# Altium schematic sheet Pwr.SchDoc(Pwr)
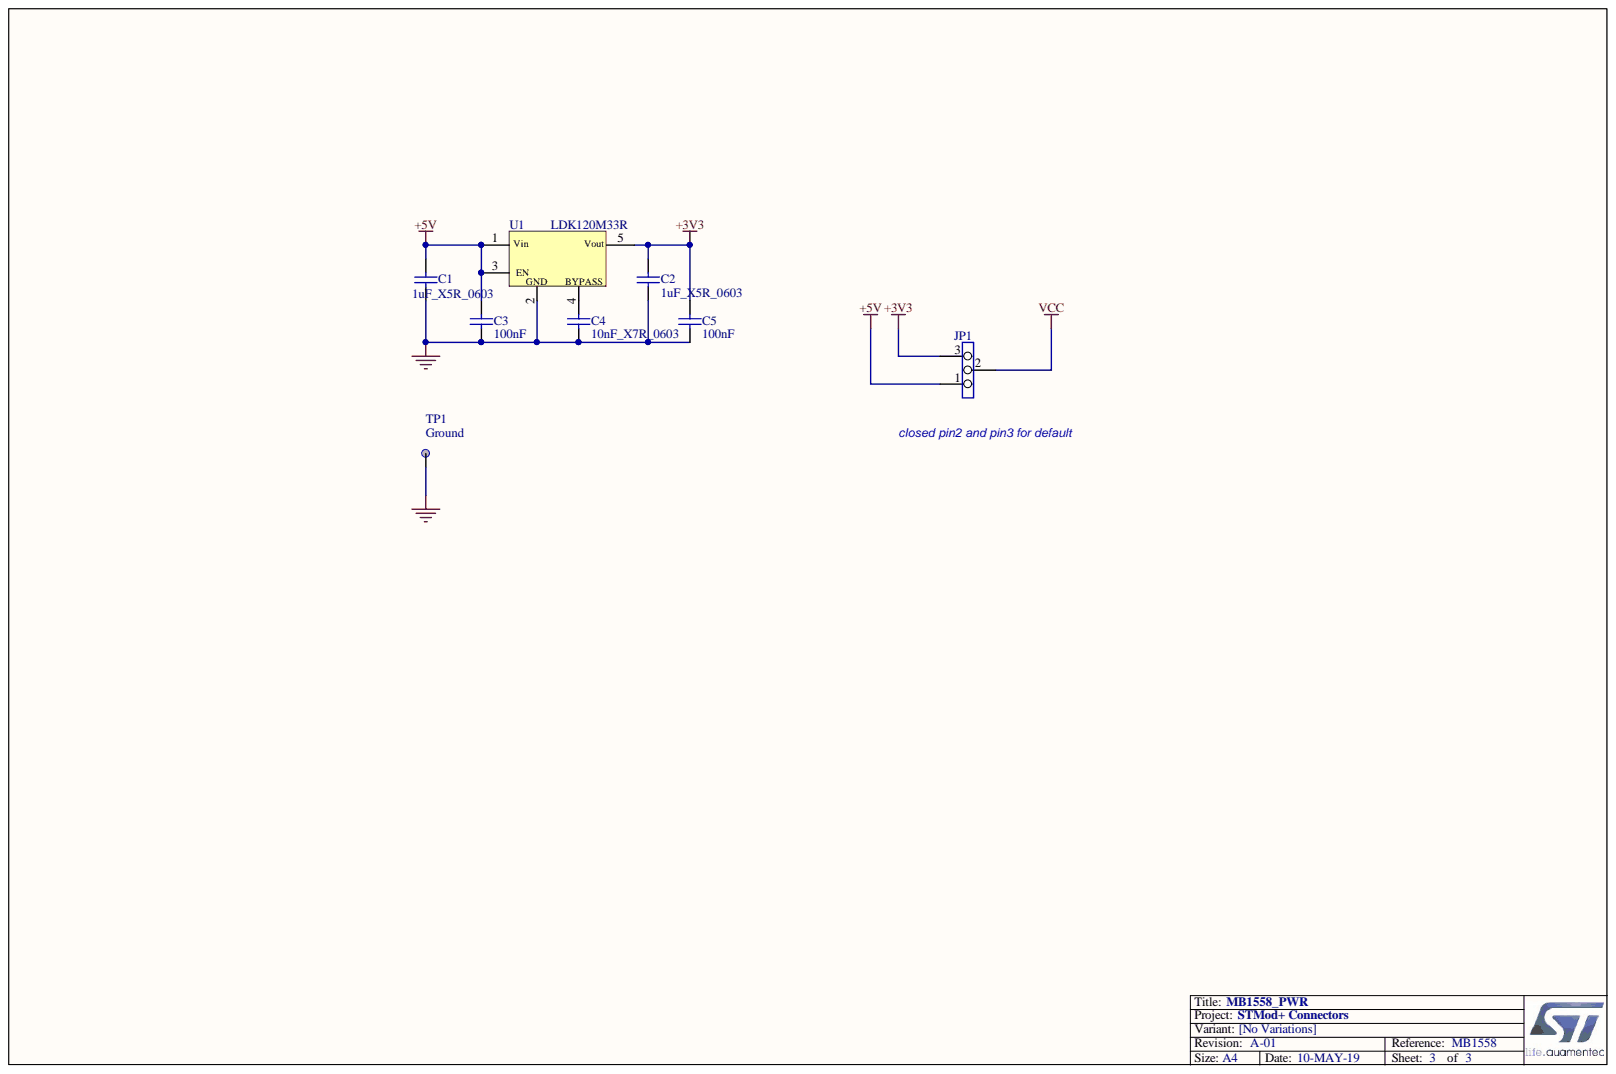
[diagram p0: Pwr.SchDoc]

## Parts
C1  1uF_X5R_0603  [0603_CAP_NPOL]
C2  1uF_X5R_0603  [0603_CAP_NP]
C3  100nF  [C0402]
C4  10nF_X7R_0603  [C0402]
C5  100nF  [C0402]
JP1  (no value)  [HDR1X3]
TP1  Ground  [TP_C4MM_PAD]
U1  LDK120M33R  [SOT-23-5L]

## Nets
+3V3: C2.1 C5.2 JP1.3 U1.5(Vout)
+5V: C1.2 C3.2 JP1.1 U1.1(Vin) U1.3(EN)
GND: C1.1 C2.2 C3.1 C4.1 C5.1 TP1.1 U1.2
VCC: JP1.2
NetC4_2: C4.2 U1.4

## Library data of the parts
C1 C2 = CAP SMD 0603  X5R 1礔 25V 10%: 0603  X5R 1礔; Tolerance: 10%; Rated voltage: 25V; Value: 1礔; Temperature Min: -55癈; Temperature Max: +125癈; Technology: X5R; Simulation: Capacitor; Signal Integrity: Capacitor; PCB3D: 0603_0_0000_R; Order code Farnell: 1288202RL; Library Name: MB963_1.SCHLIB; Component Kind: Standard
C3 C5 = Capacitor_ST: Capacitor 0402 / 0603 / 0805; Value: 100nF; Simulation: CAP; Signal Integrity: Cap; Revision: July-2002: Re-released for DXP Platform.; Library Name: MCD_TOOLS.SchLib; Component Kind: Standard
C4 = CAP SMD 0603  X7R 10000PF 50V 10%: 0402  X7R 10NF; Tolerance: 10%; Rated voltage: 50V; Order code RS: 2040779; Value: 10NF; Temperature Min: -55癈; Temperature Max: +125癈; Technology: X7R; Simulation: Capacitor; Signal Integrity: Capacitor; PCB3D: 0603_0_0000_R; Order code Farnell: 7569548RL; Library Name: MB963_1.SCHLIB; Component Kind: Standard
JP1 = Jumper3: Library Name: STR910_SCHLIB.SCHLIB; Component Kind: Standard
TP1 = TP: Component Kind: Standard
U1 = LDK120M33R: 200 mA low quiescent current very low noise LDO; Manuf_1: STM; Ref St: 9176161; Provided: ST; ECCN_US/EU: EAR99/NEC; Order: LDK120M33R; Manuf_Part_1: LDK120M33R; Library Name: MB1246.SCHLIB; Distrib: ST; Component Kind: Standard
